# Altium schematic sheet A1-POWER.SchDoc
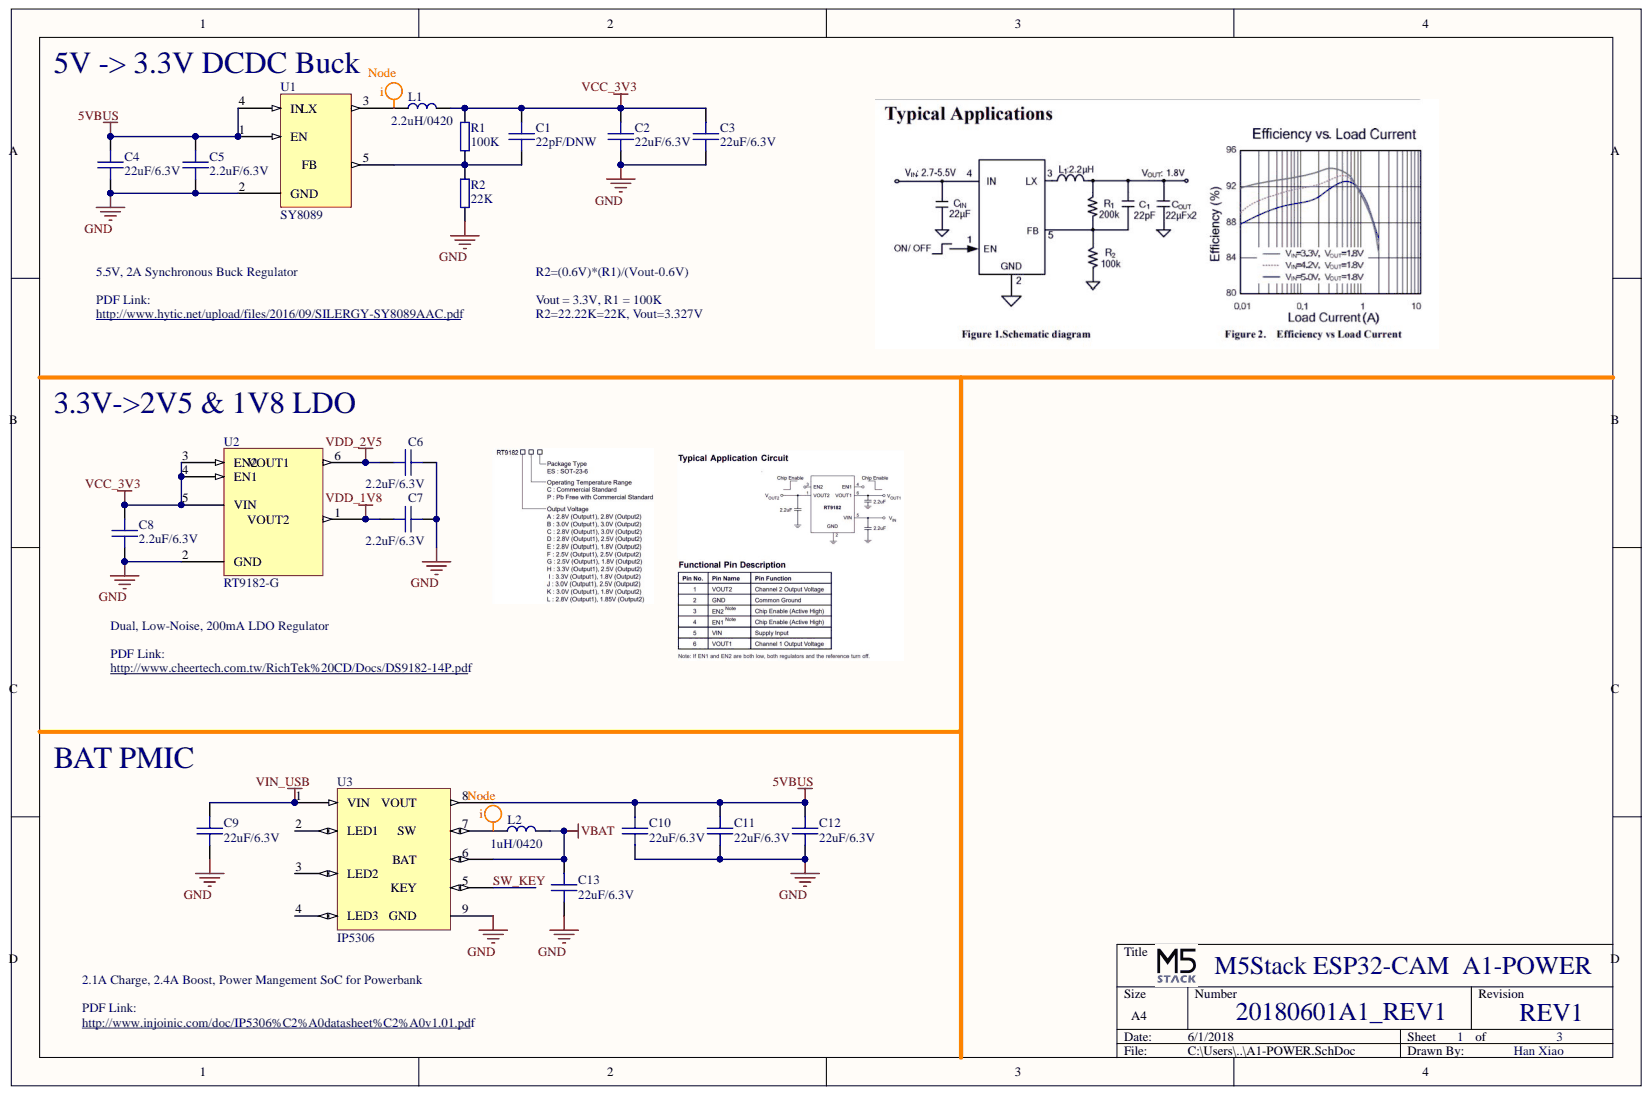
[diagram p0: A1-POWER.SchDoc]

## Parts
C1  22pF/DNW  [SMT_C_0402]
C2  22uF/6.3V  [SMT_C_0805]
C3  22uF/6.3V  [SMT_C_0805]
C4  22uF/6.3V  [SMT_C_0805]
C5  2.2uF/6.3V  [SMT_C_0402]
C6  2.2uF/6.3V  [SMT_C_0402]
C7  2.2uF/6.3V  [SMT_C_0402]
C8  2.2uF/6.3V  [SMT_C_0402]
C9  22uF/6.3V  [SMT_C_0805]
C10  22uF/6.3V  [SMT_C_0805]
C11  22uF/6.3V  [SMT_C_0805]
C12  22uF/6.3V  [SMT_C_0805]
C13  22uF/6.3V  [SMT_C_0805]
L1  2.2uH/0420  [SMT_L_3.3mm]
L2  1uH/0420  [SMT_L_3.3mm]
R1  100K  [SMT_R_0402]
R2  22K  [SMT_R_0402]
U1  SY8089  [SOT23-5]
U2  RT9182-G  [SOT23-6]
U3  IP5306  [AD620-SOP8]

## Nets
5VBUS: C4.1 C5.1 C10.1 C11.1 C12.1 U1.1(EN) U1.4(IN) U3.8
GND: C2.2 C3.2 C4.2 C5.2 C6.2 C7.2 C8.2 C9.2 C10.2 C11.2 C12.2 C13.2 R2.1 U1.2(GND) U2.2(GND) U3.9
VBAT: C13.1 L2.1 U3.6
VCC_3V3: C1.1 C2.1 C3.1 C8.1 L1.1 R1.2 U2.3(EN2) U2.4(EN1) U2.5(VIN)
VDD_1V8: C7.1 U2.1
VDD_2V5: C6.1 U2.6
VIN_USB: C9.1 U3.1(VIN)
SW_KEY: U3.5
NetC1_2: C1.2 R1.1 R2.2 U1.5
NetL1_2: L1.2 U1.3
NetL2_2: L2.2 U3.7

Not connected: U3 2(LED1) 3(LED2) 4(LED3)

## Library data of the parts
C1 C5-C8 = SMT_C_0402: Surface mount capacitor 0402; Component Kind: Standard; Library Name: Vowstar_Basic_Device.IntLib
C2-C4 C9-C13 = SMT_C_0805: Surface mount capacitor 0805; Component Kind: Standard; Library Name: Vowstar_Basic_Device.IntLib
L1 L2 = SMT_L_3.3mm: LPO3310 SMT Power Inductor, 3.3x3.3x1mm; Component Kind: Standard; Library Name: Vowstar_Basic_Device.IntLib
R1 R2 = SMT_R_0402: Surface mount resistor 0402; Component Kind: Standard; Library Name: Vowstar_Basic_Device.IntLib
U1 = SY8089: High Efficiency 5.5V, 2A continuous, 3A peak, 1MHz  Synchronous Step Down Regulator; Component Kind: Standard; Library Name: DCDC-CONVERTER.SchLib
U2 = RT9182: The RT9182 is a dual-channel, low noise, and low dropout  regulator supplying up to 200mA current at each channel.  The output voltage ranges from 1.5V to 3.3V in 100mV  increments and 2% accuracy by operating from a +2.7V  to +5.5V input.; Component Kind: Standard; Library Name: LV-POWER-MAN.SchLib
U3 = IP5306: Component Kind: Standard; Library Name: BAT-MANG.SchLib
